# Altium schematic sheet bldc_ble.SchDoc
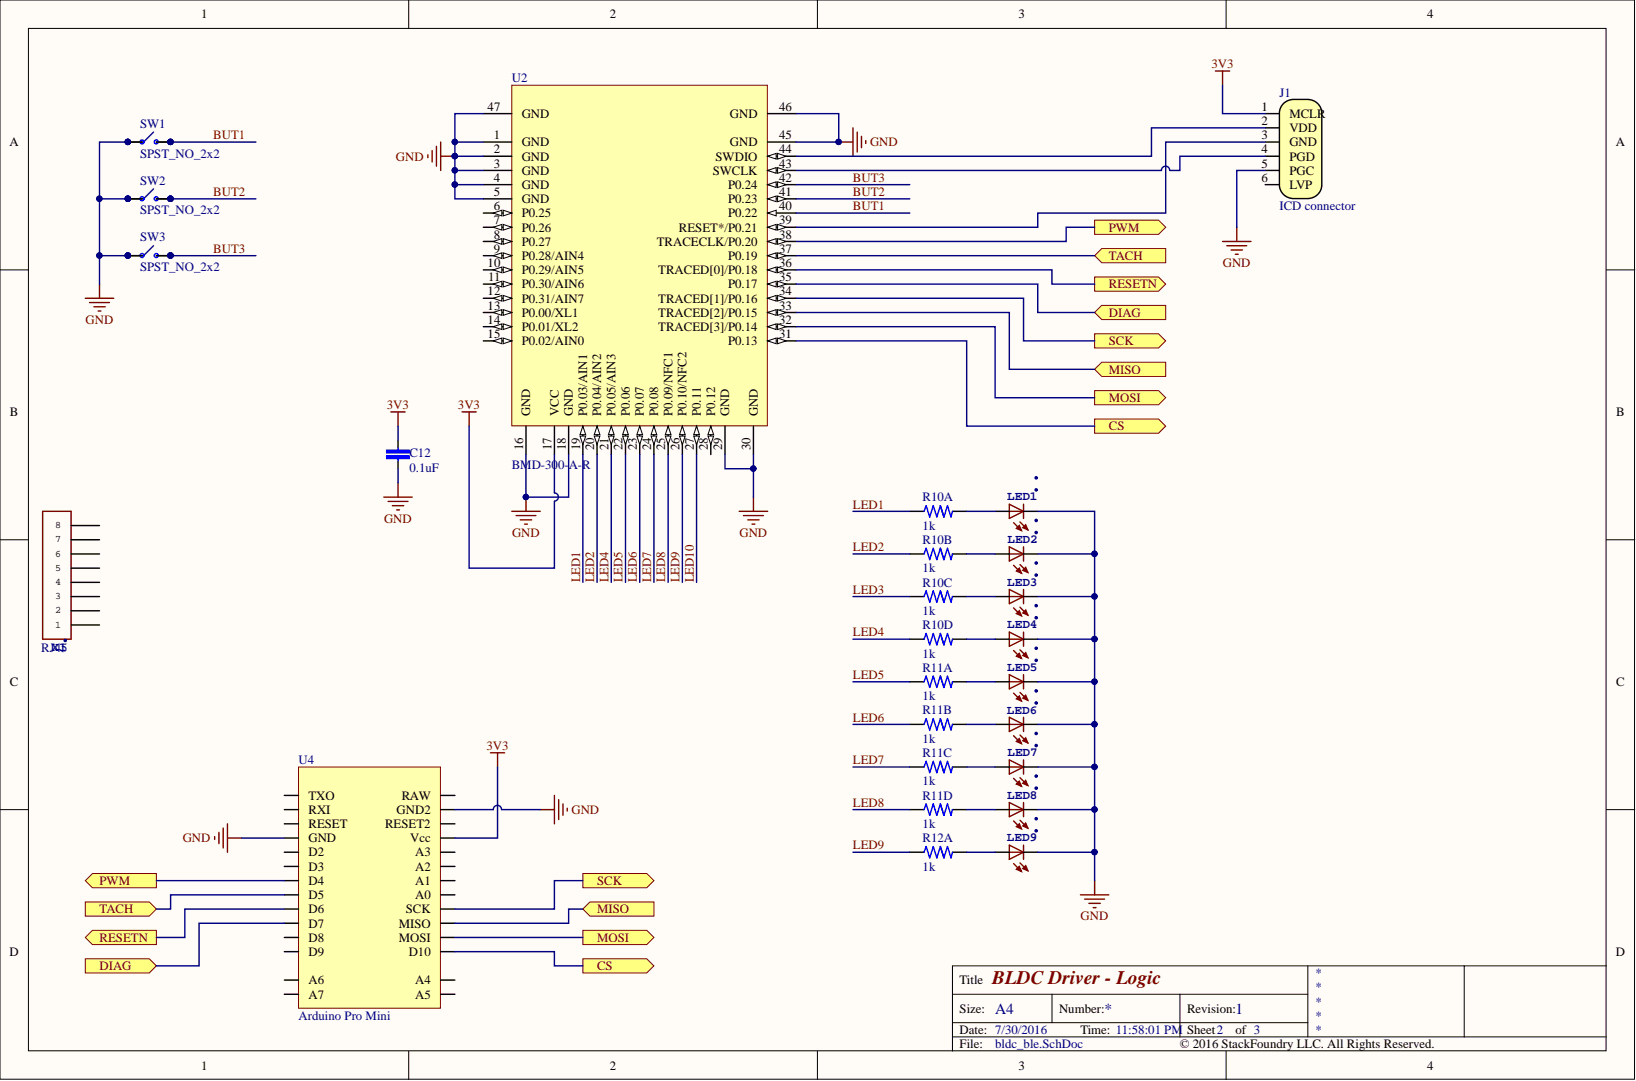
[diagram p0: bldc_ble.SchDoc]

## Parts
C12  0.1uF  [C0603]
J1  ICD connector  [TC2030-MCP-NL]  (no BOM)
LED1  (no value)  [LED-0603]
LED2  (no value)  [LED-0603]
LED3  (no value)  [LED-0603]
LED4  (no value)  [LED-0603]
LED5  (no value)  [LED-0603]
LED6  (no value)  [LED-0603]
LED7  (no value)  [LED-0603]
LED8  (no value)  [LED-0603]
LED9  (no value)  [LED-0603]
R10  1k  [R0402x4]  (parts A,B,C,D)
R11  1k  [R0402x4]  (parts A,B,C,D)
R12  1k  [R0402x4]  (part A)
SW1  SPST_NO_2x2  [PTS645]
SW2  SPST_NO_2x2  [PTS645]
SW3  SPST_NO_2x2  [PTS645]
U2  BMD-300  Manufacturer P/N: BMD-300-A-R  [BMD-300]
U4  Arduino Pro Mini  [ARDUINO_PRO_MINI]
X1  RJ45  [RJ45_SMT]

## Ports
CS, DIAG, MISO, MOSI, PWM, RESETN, SCK, TACH

## Nets
3V3: C12.1 J1.1 U2.17(VCC) U4.Vcc
GND: C12.2 J1.5(PGC) LED1.C LED2.C LED3.C LED4.C LED5.C LED6.C LED7.C LED8.C LED9.C SW1.1 SW1.2 SW2.1 SW2.2 SW3.1 SW3.2 U2.1(GND) U2.2(GND) U2.3(GND) U2.4(GND) U2.5(GND) U2.16(GND) U2.18(GND) U2.29(GND) U2.30(GND) U2.45(GND) U2.46(GND) U2.47(GND) U4.GND U4.GND2
BUT1: SW1.3 SW1.4 U2.40(P0.22)
BUT2: SW2.3 SW2.4 U2.41(P0.23)
BUT3: SW3.3 SW3.4 U2.42(P0.24)
CS: U2.31(P0.13) U4.D10 ; port CS
DIAG: U2.35(P0.17) U4.D7 ; port DIAG
LED1: R10.1 U2.19(P0.03/AIN1)
LED2: R10.3 U2.20(P0.04/AIN2)
LED3: R10.5
LED4: R10.7 U2.21(P0.05/AIN3)
LED5: R11.1 U2.22(P0.06)
LED6: R11.3 U2.23(P0.07)
LED7: R11.5 U2.24(P0.08)
LED8: R11.7 U2.25(P0.09/NFC1)
LED9: R12.1 U2.26(P0.10/NFC2)
LED10: U2.27(P0.11)
MISO: U2.33(TRACED[2]/P0.15) U4.MISO ; port MISO
MOSI: U2.32(TRACED[3]/P0.14) U4.MOSI ; port MOSI
PWM: U2.38(TRACECLK/P0.20) U4.D4 ; port PWM
RESETN: U2.36(TRACED[0]/P0.18) U4.D6 ; port RESETN
SCK: U2.34(TRACED[1]/P0.16) U4.SCK ; port SCK
TACH: U2.37(P0.19) U4.D5 ; port TACH
NetJ1_2: J1.2(VDD) U2.44(SWDIO)
NetJ1_3: J1.3(GND) U2.39(RESET*/P0.21)
NetJ1_4: J1.4(PGD) U2.43(SWCLK)
NetLED1_A: LED1.A R10.2
NetLED2_A: LED2.A R10.4
NetLED3_A: LED3.A R10.6
NetLED4_A: LED4.A R10.8
NetLED5_A: LED5.A R11.2
NetLED6_A: LED6.A R11.4
NetLED7_A: LED7.A R11.6
NetLED8_A: LED8.A R11.8
NetLED9_A: LED9.A R12.2

Not connected: J1 6(LVP); U2 6(P0.25) 7(P0.26) 8(P0.27) 9(P0.28/AIN4) 10(P0.29/AIN5) 11(P0.30/AIN6) 12(P0.31/AIN7) 13(P0.00/XL1) 14(P0.01/XL2) 15(P0.02/AIN0) 28(P0.12); U4 A0 A1 A2 A3 A4 A5 A6 A7 D2 D3 D8 D9 RAW RESET RESET2 RXI TXO; X1 1 2 3 4 5 6 7 8

## Library data of the parts
C12 = C-EU: Component Kind: Standard; Library Name: rcl.IntLib
J1 = TC2030-MCP-NL: Conector par ICD; Library Name: TC2030.IntLib
LED1-LED9 = LED0603: Component Kind: Standard; Library Name: general.IntLib
R10-R12 = R-US-4ch: Component Kind: Standard; Library Name: rcl.IntLib
U2 = BMD-300-A: Bluetooth 4.2 LE Module, nRF52832, 512kB Flash, 64kB RAM; Manufacturer: Rigado; Added By: Cam N; Internal Antenna: Yes; Protocol: BLE 4.2; RF Freq: 2.4GHz; Added Date: 10/14/2015; Component Kind: Standard; Height: 1.9mm; Library Name: BMD-300.IntLib; Part Number: BMD-300-A; Size: 14mm x 9.8mm
SW1-SW3 = SPST_NO_2x2: Component Kind: Standard; Library Name: switches.IntLib
U4 = Arduino Pro Mini: Component Kind: Standard; Library Name: arduino.IntLib
X1 = RJ45: Component Kind: Standard; Library Name: connectors.IntLib
